# Altium schematic sheet Pwr&MCU.SchDoc
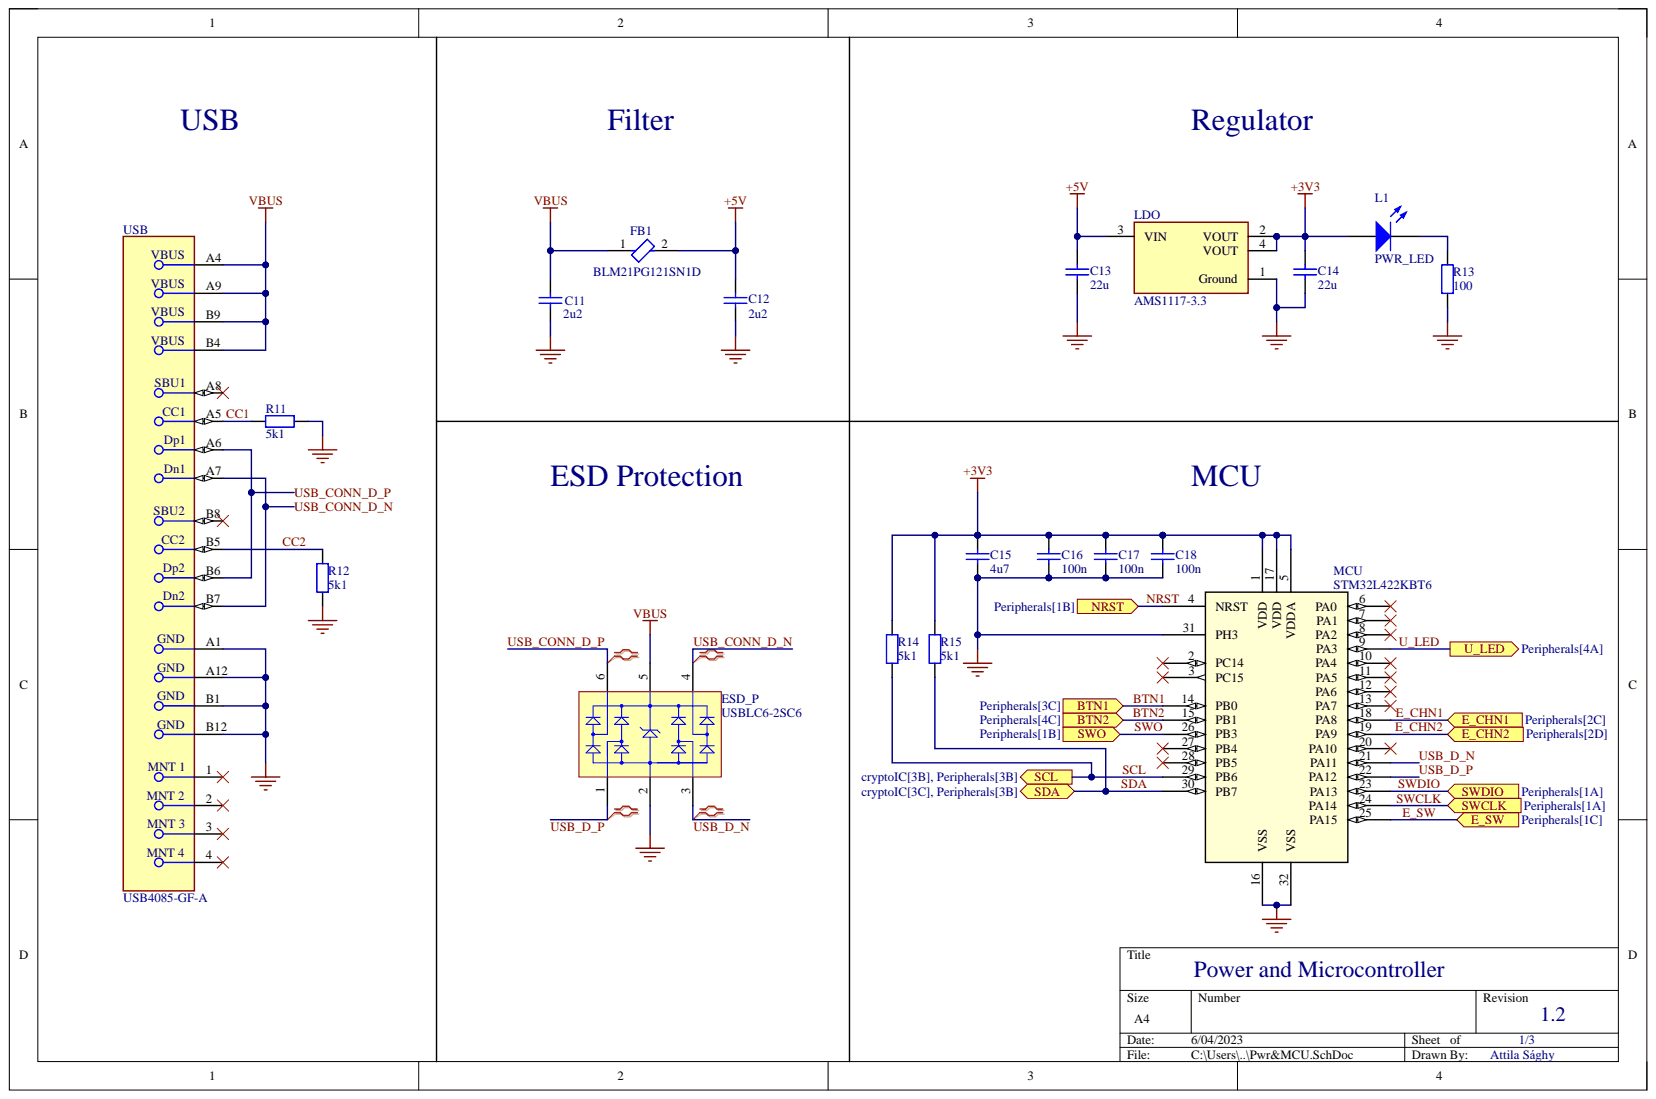
[diagram p0: Pwr&MCU.SchDoc]

## Parts
C11  2u2  [FP_0805_CAP_085]
C12  2u2  [FP_0805_CAP_085]
C13  22u  [FP_0805_CAP_085]
C14  22u  [FP_0805_CAP_085]
C15  4u7  [FP_0805_CAP_085]
C16  100n  [FP_0805_CAP_085]
C17  100n  [FP_0805_CAP_085]
C18  100n  [FP_0805_CAP_085]
ESD_P  USBLC6-2SC6  [STM-SOT23-6L_V]
FB1  BLM21PG121SN1D  [FP-BLM21-0_2-t0_85-e0_5-d-IPC_C]
L1  PWR_LED  [FP_0805_LED_RED]
LDO  AMS1117-3.3  [FP-AMS1117-IPC_C]
MCU  STM32L422KBT6  [LQFP_422KBT6_STM]
R11  5k1  [FP_0805_RES]
R12  5k1  [FP_0805_RES]
R13  100  [FP_0805_RES]
R14  5k1  [FP_0805_RES]
R15  5k1  [FP_0805_RES]
USB  USB4085-GF-A  [FP-USB4085-GF-A-MFG]

## Ports
BTN1, BTN2, E_CHN1, E_CHN2, E_SW, NRST, SCL, SDA, SWCLK, SWDIO, SWO, U_LED

## Nets
+3V3: C14.2 C15.2 C16.2 C17.2 C18.2 L1.1 LDO.2(VOUT) LDO.4(VOUT) MCU.1(VDD) MCU.5(VDDA) MCU.17(VDD) R14.2 R15.2
+5V: C12.2 C13.2 FB1.2 LDO.3(VIN)
GND: C11.1 C12.1 C13.1 C14.1 C15.1 C16.1 C17.1 C18.1 ESD_P.2 LDO.1(Ground) MCU.16(VSS) MCU.31(PH3) MCU.32(VSS) R11.1 R12.2 R13.1 USB.A1 USB.A12 USB.B1 USB.B12
VBUS: C11.2 ESD_P.5 FB1.1 USB.A4 USB.A9 USB.B4 USB.B9
BTN1: MCU.14(PB0) ; port BTN1
BTN2: MCU.15(PB1) ; port BTN2
CC1: R11.2 USB.A5
CC2: R12.1 USB.B5
E_CHN1: MCU.18(PA8) ; port E_CHN1
E_CHN2: MCU.19(PA9) ; port E_CHN2
E_SW: MCU.25(PA15) ; port E_SW
NRST: MCU.4(NRST) ; port NRST
SCL: MCU.29(PB6) R14.1 ; port SCL
SDA: MCU.30(PB7) R15.1 ; port SDA
SWCLK: MCU.24(PA14) ; port SWCLK
SWDIO: MCU.23(PA13) ; port SWDIO
SWO: MCU.26(PB3) ; port SWO
U_LED: MCU.9(PA3) ; port U_LED
USB_CONN_D_N: ESD_P.4 USB.A7 USB.B7
USB_CONN_D_P: ESD_P.6 USB.A6 USB.B6
USB_D_N: ESD_P.3 MCU.21(PA11)
USB_D_P: ESD_P.1 MCU.22(PA12)
NetL1_2: L1.2 R13.2

Not connected: MCU 2(PC14) 3(PC15) 6(PA0) 7(PA1) 8(PA2) 10(PA4) 11(PA5) 12(PA6) 13(PA7) 20(PA10) 27(PB4) 28(PB5); USB 1 2 3 4 A8 B8

## Library data of the parts
C11-C18 = CAP: Capacitor; Component Kind: Standard; Library Name: hallglib.SchLib
  C11 C12: Value: 2u2
  C13 C14: Value: 22u
  C15: Value: 4u7
  C16-C18: Value: 100n
ESD_P = CMP-2000-05378-1: Very low capacitance ESD protection, 3.5 pF, -40 to 125 degC, 6-Pin SOT23, RoHS, Tape and Reel; Manufacturer: STMicroelectronics; Capacitance Value: 3.5pF; Clamping Voltage: 17V; Configuration: Dual; Direction Type: Uni-Directional; Leakage Current: 0.15uA; Number of Elements: 1; Peak Pulse Current: 5A; Product Depth (mm): 1.75mm; Product Height (mm): 1.3mm; Product Length (mm): 3.05mm; Rad Hardened: No; Reverse Breakdown Voltage: 6V; Reverse Stand-off Voltage: 5V; Suppressor Type: DiodeArrays; Test Current (It): 1mA; Clamping Voltage (Max): 17V; Component Kind: Standard; Datasheet URL: http://www.st.com/st-web-ui/static/active/en/resource/technical/document/datasheet/CD00050750.pdf; Datasheet Version: Rev. 5, 10/2011; Leakage Current (Max): 0.15uA; Library Name: Altium Content Vault; Manufacturer URL: http://www.st.com/; Mounting: SurfaceMount; Mounting Style: SurfaceMount; Operating Temp Range: -40Cto125C; Operating Temperature Classification: Automotive; Operating Temperature Max Deg. C: 125C; Operating Temperature Min Deg. C: -40C; Package / Case: SOT-23; Package Description: 6-Pin Small Outline Transistor, Body 2.925 x 1.625 mm, Pitch 0.95 mm; Package Reference: SOT23-6L; Packaging: TapeandReel; Pin Count: 6; Polarity: Uni-Directional; Working Voltage: 5V; Working Voltage (Max): 5V
FB1 = CMP-0686-00612-3: Chip Ferrite Bead, 0805, 120Ω @ 100MHz, 0.03Ω, 25%, 3A; DC Resistance (DCR): 30mR; Impedance: 120R; Material: Steel; Number of Circuits: 1; Case Code (Imperial): 0805; Case Code (Metric): 2012; Case/Package: 0805; Component Kind: Standard; Current Rating: 3A; DC Resistance-Max: 30mR; Depth: 1.25mm; Height: 0.85mm; Lead Free: Lead Free; Length: 2mm; Library Name: Altium Content Vault; Max Current Rating: 3A; Max DC Current: 3A; Max Operating Temperature: 125°C; Min Operating Temperature: -55°C; Number of Lines: 1; Number of Pins: 2; Package Quantity: 1; Packaging: Tape and Reel; REACH SVHC: No SVHC; RoHS Compliant: Yes; Series: BLM21P; Termination: SMD/SMT; Test Frequency: 100MHz; Tolerance: 25%; Width: 1.25mm
L1 = LED: Typical RED GaAs LED; Component Kind: Standard; Library Name: hallglib.SchLib
LDO = CMP-209535-000001-1: LDO Voltage Regulators 1A, 3.3V; Manufacturer: Advanced Monolithic Systems; Dropout Voltage: 1.1V; Max Junction Temp: +125°C; Max Power Dissipation: 1.2W; Max Supply Voltage: 15V; Nominal Supply Current: 5mA; Number Of Outputs: 1; Output Type: Fixed; Standoff Height: 0.64mm; Automotive: No; Category: IC; Component Kind: Standard; ComponentLink2Description: Datasheet; ComponentLink2URL: http://www.advanced-monolithic.com/pdf/ds1117.pdf; Device Class L1: Integrated Circuits (ICs); Device Class L2: Power Management ICs; Device Class L3: Voltage Regulators - Linear; Height: 1.8mm; Ipc Land Pattern Name: SOT229P700X180-4; Lead Free: Yes; Library Name: Altium Content Vault; Manufacturer Part Number: AMS1117-3.3; Octopart: https://octopart.com/ams1117-3.3-advanced+monolithic+systems-25899300; Output Current: 1A; Output Voltage: 3.3V; Package: AMS1117; RoHS: TRUE; Temperature: -40°C to +125°C; Temperature Range High: +125°C; Temperature Range Low: -40°C
MCU = STM32L422KBT6: No Description Available; Mfr_Name: STMicroelectronics; Component Kind: Standard; Library Name: STM32L422KBT6.SchLib; Manufacturer_Part_Number: STM32L422KBT6; RefDes: RefDes; Type: DEV; Copyright: Copyright (C) 2023 Ultra Librarian. All rights reserved.
R11-R15 = RES: Resistor; Component Kind: Standard; Library Name: hallglib.SchLib
  R11 R12 R14 R15: Value: 5k1
  R13: Value: 100
USB = CMP-196119-000001-2: CONN RCPT USB2.0 TYPE C 24POS RA; Gender: Receptacle; Number of Positions: 16; Component Kind: Standard; Contact Material: Copper Alloy; Contact Plating: Gold; Library Name: Altium Content Vault; Orientation: Right Angle; USB Standard: USB 2.0
